# Altium schematic sheet HS40-1177-B09-MAIN BOARD.SchDoc
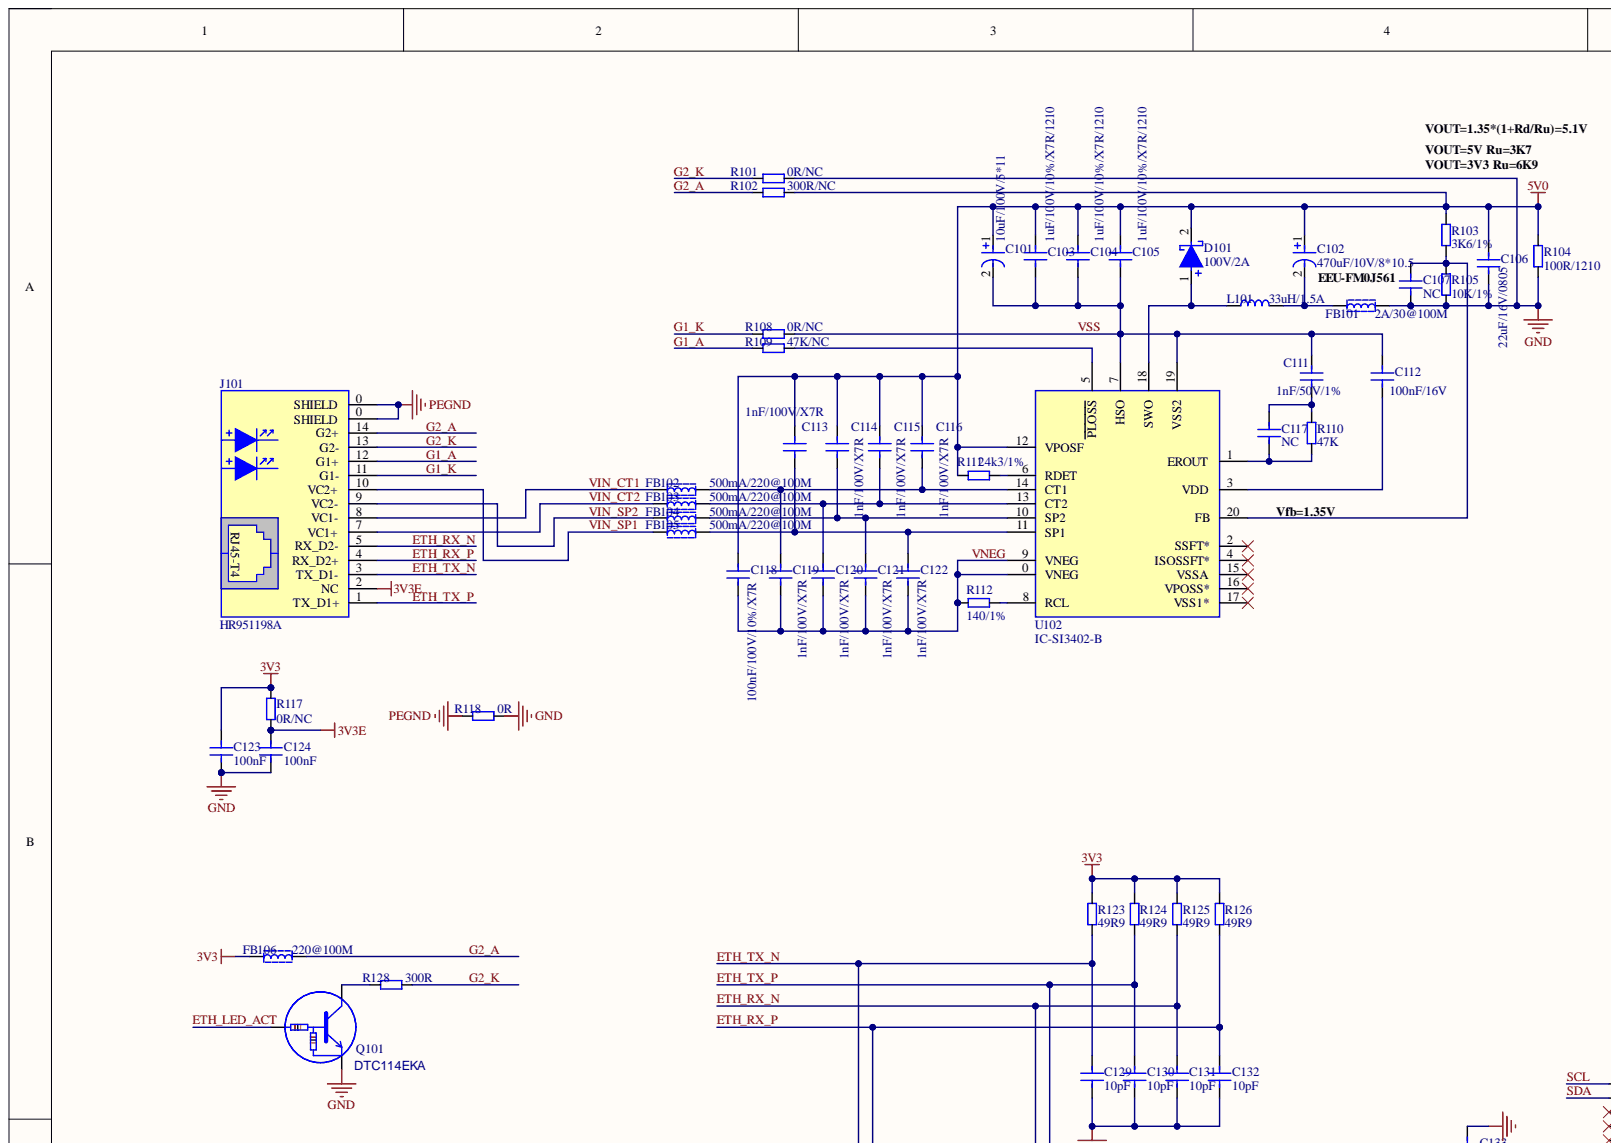
[diagram p0: HS40-1177-B09-MAIN BOARD.SchDoc, top left]
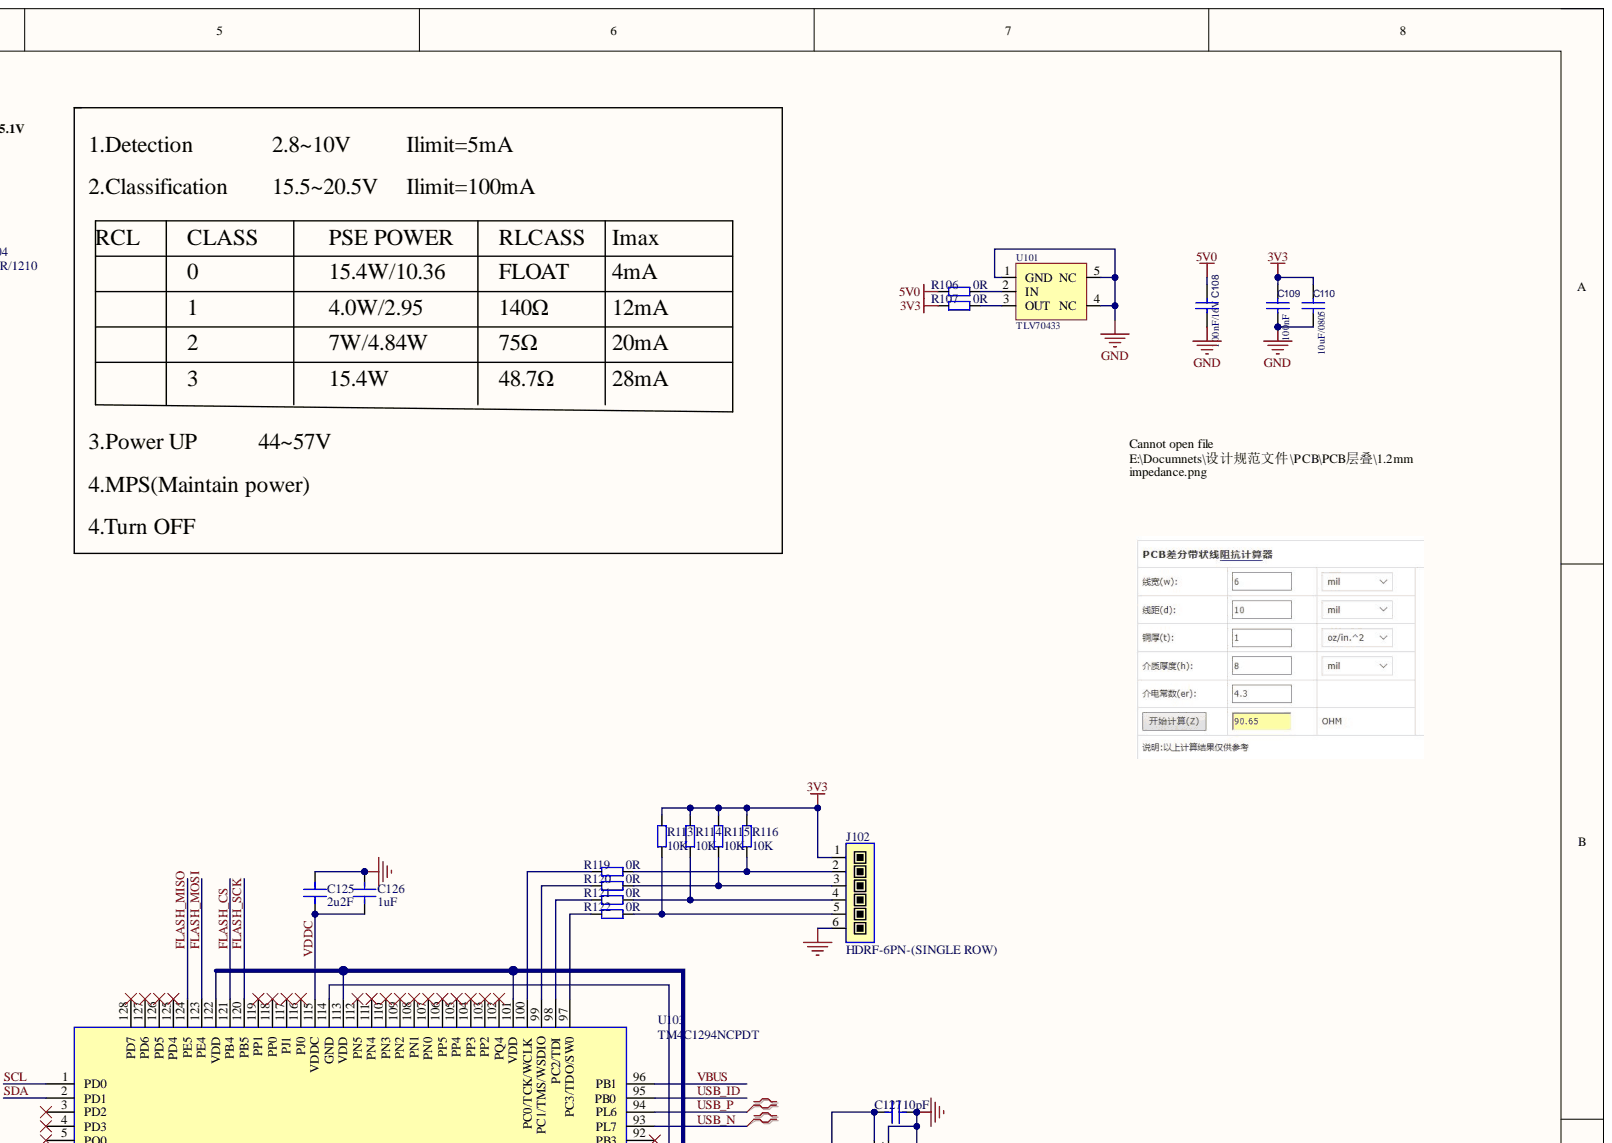
[diagram p1: HS40-1177-B09-MAIN BOARD.SchDoc, top right]
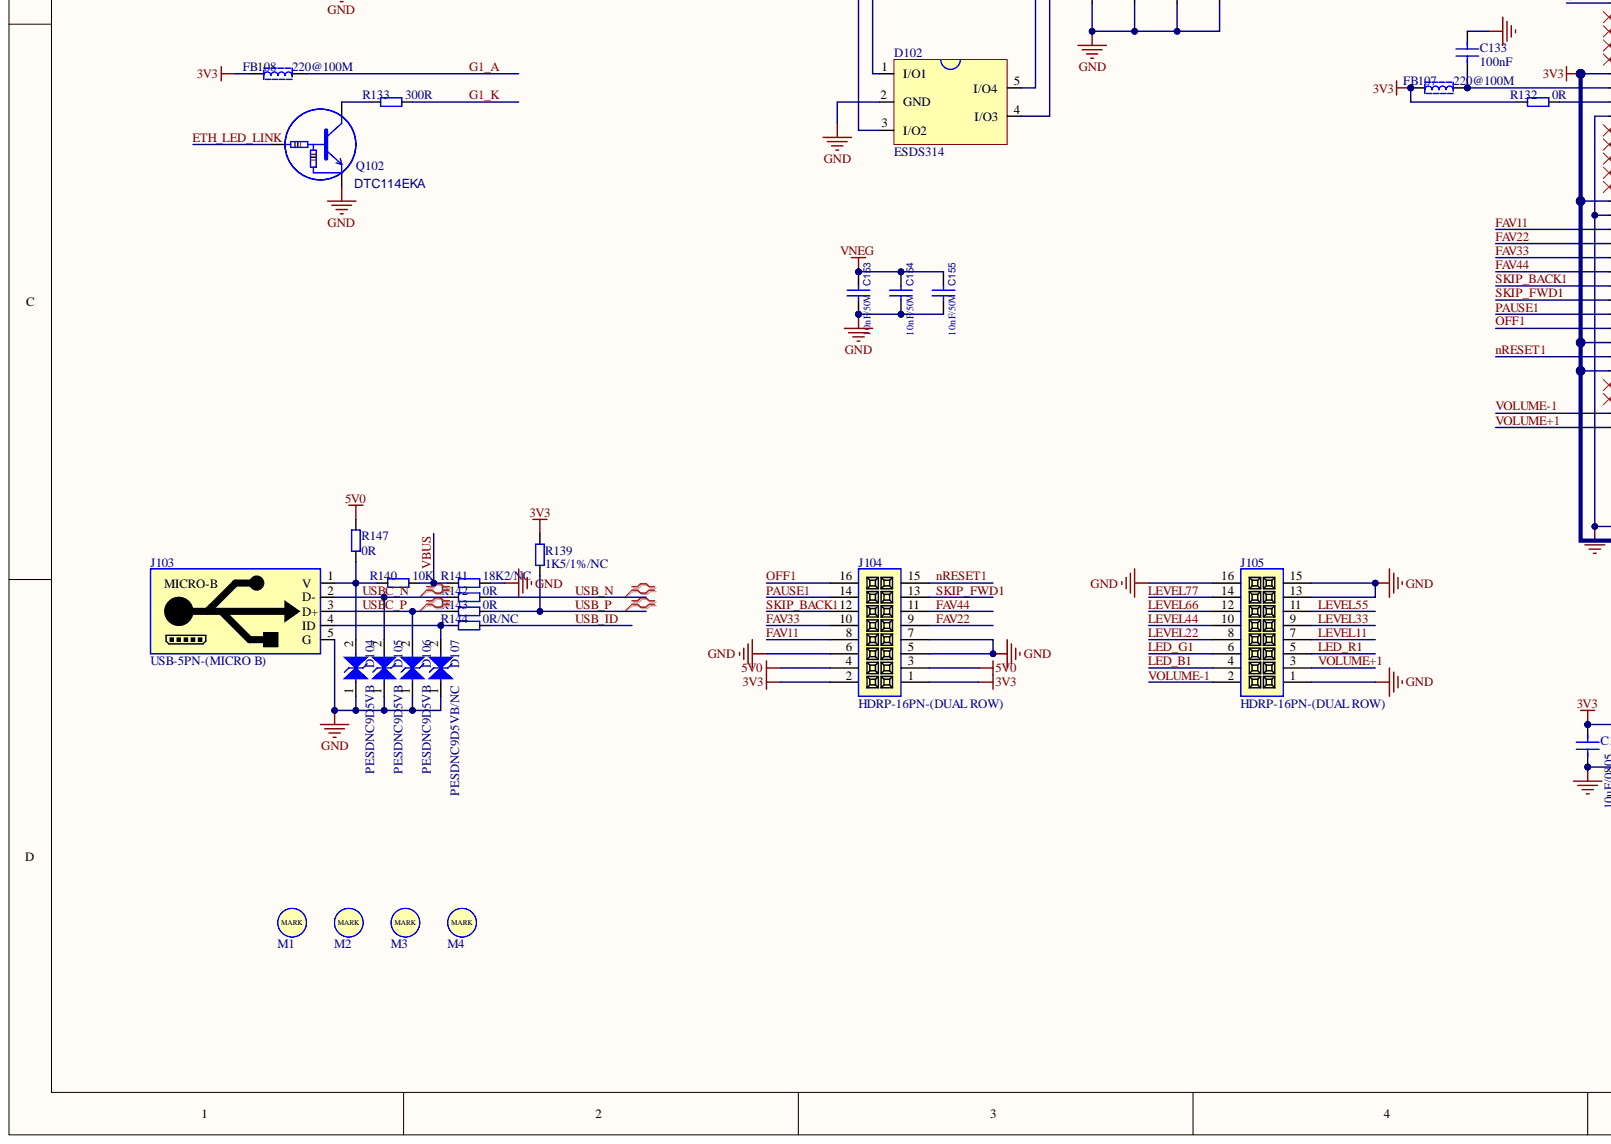
[diagram p2: HS40-1177-B09-MAIN BOARD.SchDoc, bottom left]
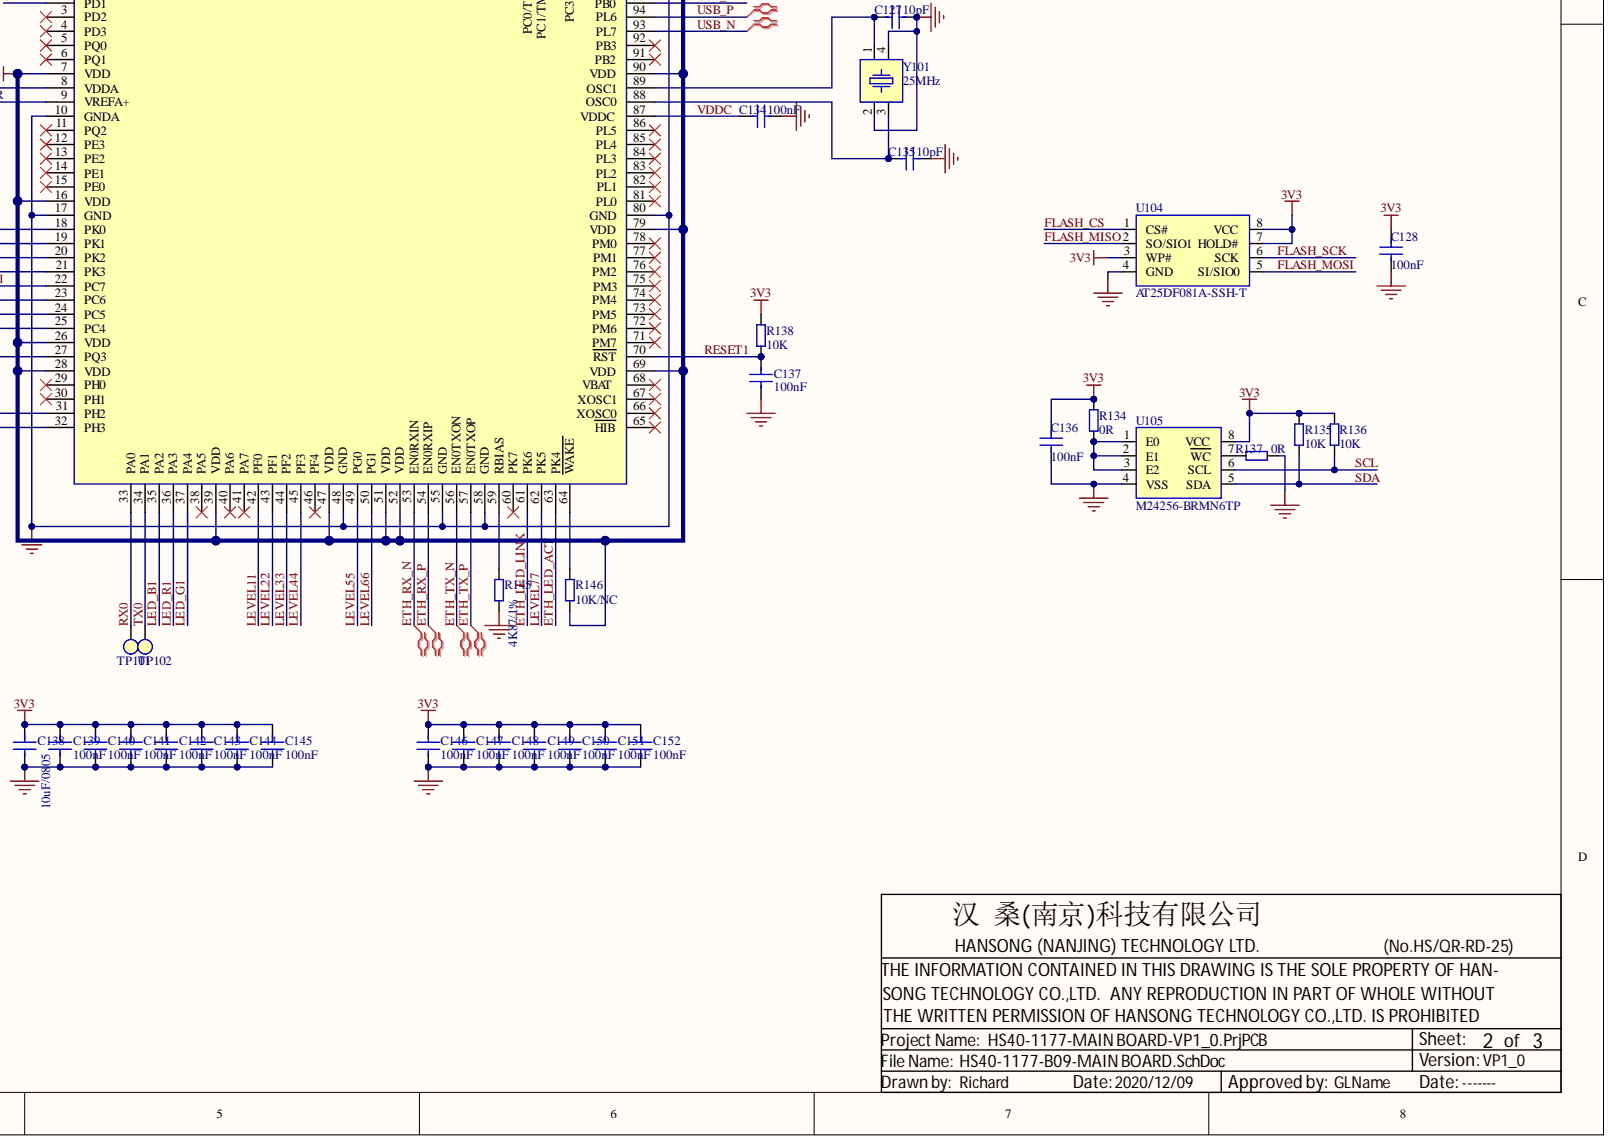
[diagram p3: HS40-1177-B09-MAIN BOARD.SchDoc, bottom right]

## Parts
C101  10uF/100V/5*11  [CE-D-2.5PH-D6.3X11.5]
C102  470uF/10V/8*10.5  [CE-8.3X8.3X12.5-(D8)]
C103  1uF/100V/10%/X7R/1210  [CC-1210]
C104  1uF/100V/10%/X7R/1210  [CC-1210]
C105  1uF/100V/10%/X7R/1210  [CC-1210]
C106  22uF/16V/0805  [CC-0805]
C107  NC  [CC-0603]
C108  100nF/16V  [CC-0402]
C109  100nF  [CC-0402]
C110  10uF/0805  [CC-0805]
C111  1nF/50V/1%  [CC-0603]
C112  100nF/16V  [CC-0402]
C113  1nF/100V/X7R  [CC-0603]
C114  1nF/100V/X7R  [CC-0603]
C115  1nF/100V/X7R  [CC-0603]
C116  1nF/100V/X7R  [CC-0603]
C117  NC  [CC-0603]
C118  100nF/100V/10%/X7R  [CC-0603]
C119  1nF/100V/X7R  [CC-0603]
C120  1nF/100V/X7R  [CC-0603]
C121  1nF/100V/X7R  [CC-0603]
C122  1nF/100V/X7R  [CC-0603]
C123  100nF  [CC-0402]
C124  100nF  [CC-0402]
C125  2u2F  [CC-0603]
C126  1uF  [CC-0603]
C127  10pF  [CC-0402]
C128  100nF  [CC-0402]
C129  10pF  [CC-0402]
C130  10pF  [CC-0402]
C131  10pF  [CC-0402]
C132  10pF  [CC-0402]
C133  100nF  [CC-0402]
C134  100nF  [CC-0402]
C135  10pF  [CC-0402]
C136  100nF  [CC-0402]
C137  100nF  [CC-0402]
C138  10uF/0805  [CC-0805]
C139  100nF  [CC-0402]
C140  100nF  [CC-0402]
C141  100nF  [CC-0402]
C142  100nF  [CC-0402]
C143  100nF  [CC-0402]
C144  100nF  [CC-0402]
C145  100nF  [CC-0402]
C146  100nF  [CC-0402]
C147  100nF  [CC-0402]
C148  100nF  [CC-0402]
C149  100nF  [CC-0402]
C150  100nF  [CC-0402]
C151  100nF  [CC-0402]
C152  100nF  [CC-0402]
C153  10nF/50V  [CC-0402]
C154  10nF/50V  [CC-0402]
C155  10nF/50V  [CC-0402]
D101  100V/2A  [DD-4.4X3.7X2.5-(SMB)]
D102  ESDS314  [ICS-5PN-0.95PH-2.9X1.6X1.5-(SOT23-5)]
D104  PESDNC9D5VB  [DZ-2PN-0.8X0.6X0.5-(SOD923)]
D105  PESDNC9D5VB  [DZ-2PN-0.8X0.6X0.5-(SOD923)]
D106  PESDNC9D5VB  [DZ-2PN-0.8X0.6X0.5-(SOD923)]
D107  PESDNC9D5VB/NC  制造商: PESDNC9D5VB  [DZ-2PN-0.8X0.6X0.5-(SOD923)]
FB101  2A/30@100M  [fb-0402]
FB102  500mA/220@100M  [fb-0402]
FB103  500mA/220@100M  [fb-0402]
FB104  500mA/220@100M  [fb-0402]
FB105  500mA/220@100M  [fb-0402]
FB106  220@100M  [fb-0402]
FB107  220@100M  [fb-0402]
FB108  220@100M  [fb-0402]
J101  HR951198A  [RJ45-D-17.6X16.9X17.5-(2 LED,POST,HR951198A)]
J102  HDRF-6PN-(SINGLE ROW)  [HDRP-D-6PN-2PH-12X2X6-(FOLLOW OTHER,42-2-000039)]
J103  USB-5PN-(MICRO B)  [USB-5PN-0.65PH-8.2X5.62X3.1-(MICRO USB,46-0-000733)]
J104  HDRP-16PN-(DUAL ROW)  [HDRP-16PN-1.27PH-10.16X3.4X5-(DUAL ROW,42-1-000009)]
J105  HDRP-16PN-(DUAL ROW)  [HDRP-16PN-1.27PH-10.16X3.4X5-(DUAL ROW,42-1-000009)]
L101  33uH/1.5A  [LL-2PN-6.5X6X4]
M1  MARK  [MARK-(ROUND,MULTI  LAYER)]
M2  MARK  [MARK-(ROUND,MULTI  LAYER)]
M3  MARK  [MARK-(ROUND,MULTI  LAYER)]
M4  MARK  [MARK-(ROUND,MULTI  LAYER)]
Q101  DTC114EKA  [TS-3PN-2.9X1.3X1.1-(SOT23,B,C,E)]
Q102  DTC114EKA  [TS-3PN-2.9X1.3X1.1-(SOT23,B,C,E)]
R101  0R/NC  [RR-0402]
R102  300R/NC  [RR-0603]
R103  3K6/1%  [RR-0603]
R104  100R/1210  [RR-1210]
R105  10K/1%  [RR-0603]
R106  0R  [RR-0402]
R107  0R  [RR-0402]
R108  0R/NC  [RR-0402]
R109  47K/NC  [RR-0603]
R110  47K  [RR-0603]
R111  24k3/1%  [RR-0603]
R112  140/1%  [RR-0603]
R113  10K  [RR-0402]
R114  10K  [RR-0402]
R115  10K  [RR-0402]
R116  10K  [RR-0402]
R117  0R/NC  [RR-0402]
R118  0R  [RR-0402]
R119  0R  [RR-0402]
R120  0R  [RR-0402]
R121  0R  [RR-0402]
R122  0R  [RR-0402]
R123  49R9  [RR-0603]
R124  49R9  [RR-0603]
R125  49R9  [RR-0603]
R126  49R9  [RR-0603]
R128  300R  [RR-0603]
R132  0R  [RR-0402]
R133  300R  [RR-0603]
R134  0R  [RR-0402]
R135  10K  [RR-0402]
R136  10K  [RR-0402]
R137  0R  [RR-0402]
R138  10K  [RR-0402]
R139  1K5/1%/NC  [RR-0402]
R140  10K  [RR-0402]
R141  18K2/NC  [RR-0402]
R142  0R  [RR-0402]
R143  0R  [RR-0402]
R144  0R/NC  [RR-0402]
R145  4K87/1%  [RR-0603]
R146  10K/NC  [RR-0402]
R147  0R  [RR-0402]
TP101  TP  [TP-D0.8]
TP102  TP  [TP-D0.8]
U101  TLV70433  [ICS-5PN-0.95PH-2.9X1.6X1.5-(SOT23-5)]
U102  IC-SI3402-B  [ICQ-20PN-0.8PH-5X5X0.9-(QFN,THERMAL PAD,Si3402-B)]
U103  TM4C1294NCPDT  [ICQ-128PN-0.4PH-14X14X1.6-(LQFP128,NO DATASHEET)]
U104  AT25DF081A-SSH-T  [ICS-8PN-1.27PH-5.28X5.28X2.2-(SOIC,NO DATASHEET)]
U105  M24256-BRMN6TP  [ICS-8PN-1.27PH-4.9X3.9X1.8-(SOP8)]
Y101  25MHz  [XL-4PN-3.2X2.5X0.8]

## Nets
3V3: C109.2 C110.2 C123.2 C128.2 C136.2 C138.2 C139.2 C140.2 C141.2 C142.2 C143.2 C144.2 C145.2 C146.2 C147.2 C148.2 C149.2 C150.2 C151.2 C152.2 FB106.1 FB107.1 FB108.1 J102.1 J104.1 J104.2 R107.2 R113.2 R114.2 R115.2 R116.2 R117.1 R123.1 R124.1 R125.1 R126.1 R132.2 R134.1 R135.2 R136.2 R138.2 R139.1 R146.1 U103.7(VDD) U103.16(VDD) U103.26(VDD) U103.28(VDD) U103.39(VDD) U103.47(VDD) U103.51(VDD) U103.52(VDD) U103.69(VDD) U103.79(VDD) U103.90(VDD) U103.101(VDD) U103.113(VDD) U103.122(VDD) U104.3(WP#) U104.7(HOLD#) U104.8(VCC) U105.8(VCC)
3V3E: C124.2 J101.2(NC) R117.2
5V0: C101.1 C102.1 C103.2 C104.2 C105.2 C106.2 C108.2 C113.2 C114.2 C115.2 C116.2 C118.2 D101.2 J104.3 J104.4 R102.1 R103.2 R104.2 R106.2 R111.2 R147.1 U102.12(VPOSF)
GND: C106.1 C107.1 C108.1 C109.1 C110.1 C123.1 C124.1 C125.2 C126.2 C127.1 C128.1 C129.1 C130.1 C131.1 C132.1 C133.1 C134.1 C135.1 C136.1 C137.1 C138.1 C139.1 C140.1 C141.1 C142.1 C143.1 C144.1 C145.1 C146.1 C147.1 C148.1 C149.1 C150.1 C151.1 C152.1 C153.1 C154.1 C155.1 D102.2(GND) D104.1 D105.1 D106.1 D107.1 FB101.1 J102.6 J103.5(G) J104.5 J104.6 J104.7 J105.1 J105.13 J105.15 J105.16 Q101.e Q102.e R101.1 R104.1 R105.1 R118.2 R137.2 R141.1 R145.1 U101.1(GND) U101.4(NC) U101.5(NC) U103.10(GNDA) U103.17(GND) U103.48(GND) U103.55(GND) U103.58(GND) U103.80(GND) U103.114(GND) U104.4(GND) U105.4(VSS) Y101.2 Y101.4
PEGND: J101.0(SHIELD) R118.1
ETH_LED_ACT: Q101.b U103.63
ETH_LED_LINK: Q102.b U103.61(PK6)
ETH_RX_N: C131.2 D102.5(I/O4) J101.5(RX_D2-) R125.2 U103.53(EN0RXIN)
ETH_RX_P: C132.2 D102.1(I/O1) J101.4(RX_D2+) R126.2 U103.54(EN0RXIP)
ETH_TX_N: C129.2 D102.3(I/O2) J101.3(TX_D1-) R123.2 U103.56(EN0TXON)
ETH_TX_P: C130.2 D102.4(I/O3) J101.1(TX_D1+) R124.2 U103.57(EN0TXOP)
FAV11: J104.8 U103.18(PK0)
FAV22: J104.9 U103.19(PK1)
FAV33: J104.10 U103.20(PK2)
FAV44: J104.11 U103.21(PK3)
FLASH_CS: U103.121(PB4) U104.1(CS#)
FLASH_MISO: U103.124(PE5) U104.2(SO/SIO1)
FLASH_MOSI: U103.123(PE4) U104.5(SI/SIO0)
FLASH_SCK: U103.120(PB5) U104.6(SCK)
G1_A: FB108.2 J101.12(G1+) R109.2
G1_K: J101.11(G1-) R108.2 R133.1
G2_A: FB106.2 J101.14(G2+) R102.2
G2_K: J101.13(G2-) R101.2 R128.1
LED_B1: J105.4 U103.35(PA2)
LED_G1: J105.6 U103.37(PA4)
LED_R1: J105.5 U103.36(PA3)
LEVEL11: J105.7 U103.42(PF0)
LEVEL22: J105.8 U103.43(PF1)
LEVEL33: J105.9 U103.44(PF2)
LEVEL44: J105.10 U103.45(PF3)
LEVEL55: J105.11 U103.49
LEVEL66: J105.12 U103.50(PG1)
LEVEL77: J105.14 U103.62(PK5)
nRESET1: J104.15 U103.27(PQ3)
OFF1: J104.16 U103.25(PC4)
PAUSE1: J104.14 U103.24(PC5)
RESET1: C137.2 R138.1 U103.70(RST)
RX0: TP101.1 U103.33(PA0)
SCL: R136.1 U103.1(PD0) U105.6(SCL)
SDA: R135.1 U103.2(PD1) U105.5(SDA)
SKIP_BACK1: J104.12 U103.22(PC7)
SKIP_FWD1: J104.13 U103.23(PC6)
TX0: TP102.1 U103.34(PA1)
USB_ID: R144.1 U103.95(PB0)
USB_N: R142.1 U103.93(PL7)
USB_P: R139.2 R143.1 U103.94(PL6)
USBC_N: D105.2 J103.2(D-) R142.2
USBC_P: D106.2 J103.3(D+) R143.2
VBUS: R140.1 R141.2 U103.96(PB1)
VDDC: C125.1 C126.1 C134.2 U103.87(VDDC) U103.115(VDDC)
VIN_CT1: FB102.2 J101.8(VC1-)
VIN_CT2: FB103.2 J101.7(VC1+)
VIN_SP1: FB105.2 J101.10(VC2+)
VIN_SP2: FB104.2 J101.9(VC2-)
VNEG: C118.1 C119.1 C120.1 C121.1 C122.1 C153.2 C154.2 C155.2 R112.2 U102.0(VNEG) U102.9(VNEG)
VOLUME+1: J105.3 U103.32(PH3)
VOLUME-1: J105.2 U103.31(PH2)
VSS: C101.2 C103.1 C104.1 C105.1 C111.2 C112.2 R108.1 U102.7(HSO) U102.19(VSS2)
NetC102_2: C102.2 FB101.2 L101.1
NetC107_2: C107.2 R103.1 R105.2 U102.20(FB)
NetC111_1: C111.1 C117.2 R110.2
NetC112_1: C112.1 U102.3(VDD)
NetC113_1: C113.1 C122.2 FB105.1 U102.11(SP1)
NetC114_1: C114.1 C121.2 FB104.1 U102.10(SP2)
NetC115_1: C115.1 C120.2 FB103.1 U102.13(CT2)
NetC116_1: C116.1 C119.2 FB102.1 U102.14(CT1)
NetC117_1: C117.1 R110.1 U102.1(EROUT)
NetC127_2: C127.2 U103.89(OSC1) Y101.1
NetC133_2: C133.2 FB107.2 U103.8(VDDA)
NetC135_2: C135.2 U103.88(OSC0) Y101.3
NetD101_1: D101.1 L101.2 U102.18(SWO)
NetD104_2: D104.2 J103.1(V) R140.2 R147.2
NetD107_2: D107.2 J103.4(ID) R144.2
NetJ102_2: J102.2 R116.1 R119.1
NetJ102_3: J102.3 R115.1 R120.1
NetJ102_4: J102.4 R114.1 R121.1
NetJ102_5: J102.5 R113.1 R122.1
NetQ101_c: Q101.c R128.2
NetQ102_c: Q102.c R133.2
NetR106_1: R106.1 U101.2(IN)
NetR107_1: R107.1 U101.3(OUT)
NetR109_1: R109.1 U102.5(PLOSS)
NetR111_1: R111.1 U102.6(RDET)
NetR112_1: R112.1 U102.8(RCL)
NetR119_2: R119.2 U103.100(PC0/TCK/WCLK)
NetR120_2: R120.2 U103.99(PC1/TMS/WSDIO)
NetR121_2: R121.2 U103.98
NetR122_2: R122.2 U103.97(PC3/TDO/SW0)
NetR132_1: R132.1 U103.9(VREFA+)
NetR134_2: R134.2 U105.1(E0) U105.2(E1) U105.3(E2)
NetR137_1: R137.1 U105.7(WC)
NetR145_2: R145.2 U103.59(RBIAS)
NetR146_2: R146.2 U103.64(WAKE)

Not connected: U102 2(SSFT*) 4(ISOSSFT*) 15(VSSA) 16(VPOSS*) 17(VSS1*); U103 3(PD2) 4(PD3) 5(PQ0) 6(PQ1) 11(PQ2) 12(PE3) 13(PE2) 14(PE1) 15(PE0) 29(PH0) 30(PH1) 38(PA5) 40(PA6) 41(PA7) 46(PF4) 60(PK7) 65(HIB) 66(XOSC0) 67(XOSC1) 68(VBAT) 71(PM7) 72(PM6) 73(PM5) 74(PM4) 75(PM3) 76(PM2) 77(PM1) 78(PM0) 81(PL0) 82(PL1) 83(PL2) 84(PL3) 85(PL4) 86(PL5) 91(PB2) 92(PB3) 102(PQ4) 103(PP2) 104(PP3) 105(PP4) 106(PP5) 107(PN0) 108(PN1) 109(PN2) 110(PN3) 111(PN4) 112 116(PJ0) 117(PJ1) 118(PP0) 119(PP1) 125(PD4) 126(PD5) 127(PD6) 128(PD7)

## Library data of the parts
C101 = CE: Electrolytic Capacitor; ABC等级: C; 封装: Pitch=2.5mm,5*11mm; 计量单位: pcs; 交期(天): 90; 旧品号: 06-10012-04; 品号规格: RD-series,10uF,100V,5*11/F2.5; 品号类型: 采购件; 品号状态: 正常(Active); 品号状态1: 生效; 品名: 编带铝电解电容; 品牌: Samwha; 确认状态: 确认; 湿敏: 0; 新品号: 23-2-000081; 英文名: Alu Electrolytic Capacitor; 制造商型号: RD2A106M05011PC380; ABC等级1: C; Component Kind: Standard; Pin: 0; 创建日期: 2015/5/8 13:56:38; 限量: 0; Library Name: Hansong-Standard SCH Library.SchLib
C102 = CE: Electrolytic Capacitor; ABC等级: C; 封装: 8×10.5mm; 计量单位: pcs; 交期(天): 63; 旧品号: 06-47111-27; 品号规格: 470uF,10V,±20%,105℃, VZH471M1ATR-0810; 品号类型: 采购件; 品号状态: 正常(Active); 品号状态1: 生效; 品名: 贴片铝电解电容; 品牌: LELON; 确认状态: 确认; 湿敏: 0; 新品号: 23-1-000010; 英文名: Chip Alu Electrolytic Capacitor; 制造商型号: VZH471M1ATR-0810; ABC等级1: C; Component Kind: Standard; Pin: 2; 创建日期: 2015/5/8 12:35:51; 限量: 0; Library Name: Hansong-Standard SCH Library.SchLib
C103-C105 = CC: Capacitor; ABC等级: C; 计量单位: pcs; 交期(天): 49; 旧品号: 26-10512-23; 品号规格: 1uF,100V,±10%,1210,X7R,HMK325B7105KN-T; 品号类型: 采购件; 品号状态: 正常(Active); 品号状态1: 生效; 品名: 贴片瓷片电容; 品牌: Taiyou; 确认状态: 确认; 限量: 0; 新品号: 23-1-000667; 英文名: Ceramic Chip Capacitor; 制造商: HMK325B7105KN-T; ABC等级1: C; Component Kind: Standard; Pin: 0; 创建日期: 2015/11/20 9:05:12; Library Name: Hansong-Standard SCH Library.SchLib
C106 = CC: Capacitor; ABC等级: C; 封装: 0805; 计量单位: pcs; 交期(天): 49; 品号规格: 22uK,16V,0805,X5R,CL21A226KOQNNNE; 品号类型: 采购件; 品号状态: 正常(Active); 品号状态1: 生效; 品名: 贴片瓷片电容; 品牌: Samsung; 确认状态: 确认; 湿敏: 0; 新品号: 23-1-001341; 英文名: Ceramic Chip Capacitor; 制造商型号: CL21A226KOQNNNE; ABC等级1: C; Component Kind: Standard; Pin: 2; 创建日期: 2018/5/9 15:05:55; 限量: 0; Library Name: Hansong-Standard SCH Library.SchLib
C107 C117 = CC: Capacitor; Component Kind: Standard; Library Name: Hansong-Standard SCH Library.SchLib
C108 C109 = Cap Semi: Capacitor (Semiconductor SIM Model); ABC等级: C; 封装: 0402; 计量单位: pcs; 交期(天): 49; 品号规格: 100nK,16V,0402,X7R,0402B104K160NT; 品号类型: 采购件; 品号状态: 正常(Active); 品号状态1: 生效; 品名: 贴片瓷片电容; 品牌: FH; 确认状态: 确认; 湿敏: 0; 新品号: 23-1-001652; 英文名: Ceramic Chip Capacitor; 制造商型号: 0402B104K160NT; ABC等级1: C; Component Kind: Standard; Pin: 2; 创建日期: 2018/11/20 17:08:58; 限量: 0
C110 = Cap Semi: Capacitor (Semiconductor SIM Model); ABC等级: C; 封装: 0805; 计量单位: pcs; 交期(天): 49; 旧品号: 26-10611-21; 品号规格: 10uK,16V,0805,X5R,C2012X5R1C106KT / CC0805KKX5R7BB106; 品号类型: 采购件; 品号状态: 正常(Active); 品号状态1: 生效; 品名: 贴片瓷片电容; 品牌: TDK/Phicomp; 确认状态: 确认; 湿敏: 0; 新品号: 23-1-000039; 英文名: Ceramic Chip Capacitor; ABC等级1: C; Component Kind: Standard; Pin: 2; 创建日期: 2015/5/8 12:35:54; 限量: 0
C111 C113-C116 C119-C122 = CC: Capacitor; ABC等级: C; 封装: 0603; 计量单位: pcs; 交期(天): 49; 旧品号: 26-10212-00; 品号规格: 1nK,100V,0603,X7R,C1608X7R2A102KT000N; 品号类型: 采购件; 品号状态: 正常(Active); 品号状态1: 生效; 品名: 贴片电容; 品牌: TDK/Phicomp; 确认状态: 确认; 湿敏: 0; 新品号: 23-1-000196; 英文名: Ceramic Chip Capacitor; 制造商: C1608X7R2A102KT000N; ABC等级1: C; Component Kind: Standard; Pin: 2; 创建日期: 2015/5/8 13:54:21; 限量: 0; 制造商型号: C1608X7R2A102KT000N; Library Name: Hansong-Standard SCH Library.SchLib
C112 C123 C124 C128 C133 C134 C136 C137 C139-C152 = CC: Capacitor; ABC等级: C; 封装: 0402; 计量单位: pcs; 交期(天): 49; 品号规格: 100nK,16V,0402,X7R,0402B104K160NT; 品号类型: 采购件; 品号状态: 正常(Active); 品号状态1: 生效; 品名: 贴片瓷片电容; 品牌: FH; 确认状态: 确认; 湿敏: 0; 新品号: 23-1-001652; 英文名: Ceramic Chip Capacitor; 制造商型号: 0402B104K160NT; ABC等级1: C; Component Kind: Standard; Pin: 2; 创建日期: 2018/11/20 17:08:58; 限量: 0; Library Name: Hansong-Standard SCH Library.SchLib
C118 = CC: Capacitor; ABC等级: C; 封装: 0603; 计量单位: pcs; 交期(天): 49; 品号规格: 100nK,100V,0603,X7R,CL10B104KC8NNNC; 品号类型: 采购件; 品号状态: 正常(Active); 品号状态1: 生效; 品名: 贴片瓷片电容; 品牌: Samsung; 确认状态: 确认; 湿敏: 0; 新品号: 23-1-001223; 英文名: Ceramic Chip Capacitor; 制造商型号: CL10B104KC8NNNC; ABC等级1: C; Component Kind: Standard; Pin: 2; 创建日期: 2018/3/12 16:47:24; 限量: 0; Library Name: Hansong-Standard SCH Library.SchLib
C125 = CC: Capacitor; ABC等级: C; 封装: 0603; 计量单位: pcs; 交期(天): 49; 旧品号: 26-22511-10; 品号规格: 2.2uK, 0603, 10V, X5R,CC0603KRX5R6BB225; 品号类型: 采购件; 品号状态: 正常(Active); 品号状态1: 生效; 品名: 贴片瓷片电容; 品牌: TDK/Yageo/和伸堂; 确认状态: 确认; 湿敏: 0; 新品号: 23-1-000054; 英文名: Ceramic Capacitor SMD; 制造商: CC0603KRX5R6BB225; ABC等级1: C; Component Kind: Standard; Pin: 2; 创建日期: 2015/5/8 12:35:56; 限量: 0; 制造商型号: CC0603KRX5R6BB225; Library Name: Hansong-Standard SCH Library.SchLib
C126 = CC: Capacitor; ABC等级: C; 封装: 0603; 计量单位: pcs; 交期(天): 49; 品号规格: 1uK,16V,0603,X7R,CL10B105KO8NNNC; 品号类型: 采购件; 品号状态: 正常(Active); 品号状态1: 生效; 品名: 贴片瓷片电容; 品牌: Samsung; 确认状态: 确认; 湿敏: 0; 新品号: 23-1-001131; 英文名: Ceramic Chip Capacitor; 制造商型号: CL10B105KO8NNNC; ABC等级1: C; Component Kind: Standard; Pin: 2; 创建日期: 2017/12/7 10:29:37; 限量: 0; Library Name: Hansong-Standard SCH Library.SchLib
C127 C129-C132 C135 = CC: Capacitor; ABC等级: C; 封装: 0402; 计量单位: pcs; 交期(天): 49; 品号规格: 10pJ,50V,0402,NP0,0402CG100J500NT; 品号类型: 采购件; 品号状态: 正常(Active); 品号状态1: 生效; 品名: 贴片瓷片电容; 品牌: FH; 确认状态: 确认; 湿敏: 0; 新品号: 23-1-001364; 英文名: Ceramic Chip Capacitor; 制造商型号: 0402CG100J500NT; ABC等级1: C; Component Kind: Standard; Pin: 2; 创建日期: 2018/5/10 9:47:55; 限量: 0; Library Name: Hansong-Standard SCH Library.SchLib
C138 = CC: Capacitor; ABC等级: C; 封装: 0805; 计量单位: pcs; 交期(天): 49; 旧品号: 26-10611-21; 品号规格: 10uK,16V,0805,X5R,C2012X5R1C106KT / CC0805KKX5R7BB106; 品号类型: 采购件; 品号状态: 正常(Active); 品号状态1: 生效; 品名: 贴片瓷片电容; 品牌: TDK/Phicomp; 确认状态: 确认; 湿敏: 0; 新品号: 23-1-000039; 英文名: Ceramic Chip Capacitor; ABC等级1: C; Component Kind: Standard; Pin: 2; 创建日期: 2015/5/8 12:35:54; 限量: 0; Library Name: Hansong-Standard SCH Library.SchLib
C153-C155 = Cap Semi: Capacitor (Semiconductor SIM Model); ABC等级: B; 封装: 0402; 计量单位: pcs; 交期(天): 49; 品号规格: 10nK,50V,0402,X7R,0402B103K500NT; 品号类型: 采购件; 品号状态: 正常(Active); 品号状态1: 生效; 品名: 贴片瓷片电容; 品牌: FH; 确认状态: 确认; 湿敏: 0; 新品号: 23-1-001159; 英文名: Ceramic Chip Capacitor; 制造商型号: 0402B103K500NT; ABC等级1: B; Component Kind: Standard; Pin: 2; 创建日期: 2017/12/15 11:05:01; 限量: 0
D101 = DS: Schottky BarrierDiode Diode; ABC等级: C; 封装: SMB; 计量单位: pcs; 交期(天): 30; 旧品号: 33-20002-03; 品号规格: ES2B,100V 2A; 品号类型: 采购件; 品号状态: 正常(Active); 品号状态1: 生效; 品名: 二极管; 品牌: TRR; 确认状态: 确认; 湿敏: 0; 新品号: 12-1-000151; 制造商: ES2B; ABC等级1: C; Component Kind: Standard; Pin: 2; 创建日期: 2015/5/8 13:42:53; 限量: 0; 英文名: Diode; 制造商型号: ES2B; Library Name: Hansong-Standard SCH Library.SchLib
D102 = TLV70233: ABC等级: B; PN: NEW; 封装: SOT23(5); 计量单位: pcs; 交期(天): 90; 品号规格: ESDS314,SOT23(5); 品号类型: 采购件; 品号状态: 正常(Active); 品号状态1: 生效; 品名: 贴片ESD保护IC; 品牌: TI; 确认状态: 确认; 湿敏: 1; 限量: 0; 新品号: 11-1-002193; 英文名: ESD Production IC; ABC等级1: B; Component Kind: Standard; Library Name: M33.SchLib; Pin: 5; 创建日期: 2019/10/31 12:35:17; 制造商型号: ESDS314
D104-D107 = DZ-(TVS): Zener Diode; ABC等级: C; 封装: SOD923; 计量单位: pcs; 交期(天): 42; 旧品号: 33-00905-02; 品号规格: PESDNC9D5VB,5V,80W; 品号类型: 采购件; 品号状态: 正常(Active); 品号状态1: 生效; 品名: 静电和瞬变电压抑制二极管; 品牌: Prisemi; 确认状态: 确认; 湿敏: 0; 新品号: 12-1-000041; 英文名: ESD TVS DIODE; ABC等级1: C; Component Kind: Standard; Pin: 2; 创建日期: 2015/5/8 12:21:59; 限量: 0; 制造商型号: PESDNC9D5VB; Library Name: Hansong-Standard SCH Library.SchLib
  D104-D106: 制造商: PESDNC9D5VB
FB101-FB105 = FB: Ferrite Bead; ABC等级: B; 封装: 0402; 计量单位: pcs; 交期(天): 80; 旧品号: 08-04121-18; 品号规格: 120ohm@100MHz,1.3A,±25%,0402,BLM15PD121SN1D; 品号类型: 采购件; 品号状态: 正常(Active); 品号状态1: 生效; 品名: 贴片磁珠; 品牌: Murata; 确认状态: 确认; 湿敏: 0; 新品号: 24-1-000066; 制造商: BLM15PD121SN1D; ABC等级1: B; Component Kind: Standard; Pin: 2; 创建日期: 2015/5/8 12:44:06; 限量: 0; 英文名: FERRITE; 制造商型号: BLM15PD121SN1D; Library Name: Hansong-Standard SCH Library.SchLib
FB106-FB108 = FB: Ferrite Bead; ABC等级: B; 封装: 0402; 计量单位: pcs; 交期(天): 55; 旧品号: 08-04121-17; 品号规格: 120ohm@100MHz,0.3A,±25%,0402,BLM15BD121SN1D; 品号类型: 采购件; 品号状态: 正常(Active); 品号状态1: 生效; 品名: 贴片磁珠; 品牌: Murata; 确认状态: 确认; 湿敏: 0; 新品号: 24-1-000065; 制造商: BLM15BD121SN1D; ABC等级1: B; Component Kind: Standard; Pin: 2; 创建日期: 2015/5/8 12:44:05; 限量: 0; 英文名: FERRITE; 制造商型号: BLM15BD121SN1D; Library Name: Hansong-Standard SCH Library.SchLib
J102 = HDRF-6PN-(SINGLE ROW): Female Header; Component Kind: Standard; Library Name: Hansong-Standard SCH Library.SchLib
J103 = USB-5PN-(MICRO B): USB; 计量单位: pcs; 交期(天): 45; 品号规格: MC-100503027-R; 品号类型: 采购件; 品号状态: 正常(Active); 品号状态1: 生效; 品名: 插件Micro USB接口; 品牌: 正宝; 确认状态: 确认; 湿敏: 0; 新品号: 46-0-000733; 英文名: Micro-USB; ABC等级: B; ABC等级1: B; Component Kind: Standard; Pin: 0; 创建日期: 2020/5/21 16:47:53; 限量: 0; 制造商型号: MC-100503027-R; Library Name: Hansong-Standard SCH Library.SchLib
J104 J105 = HDRP-16PN-(DUAL ROW): Pin Header; ABC等级: C; 计量单位: pcs; 交期(天): 25; 旧品号: 13-11216-02; 品号规格: 1.27mm间距,2*8Pin,CNT-M127-2*8-GM-3.5/1.5/5-100-TR; 品号类型: 采购件; 品号状态: 正常(Active); 品号状态1: 生效; 品名: 贴片双排直针; 品牌: CNT; 确认状态: 确认; 湿敏: 0; 新品号: 42-1-000009; 英文名: Connector; 制造商: CNT-M127-2*8-GM-3.5/1.5/5-100-TR; ABC等级1: C; Component Kind: Standard; Pin: 16; 创建日期: 2015/5/8 12:50:08; 限量: 0; 制造商型号: CNT-M127-2*8-GM-3.5/1.5/5-100-TR; Library Name: Hansong-Standard SCH Library.SchLib
L101 = LL: Inductor; ABC等级: B; 封装: 6045; 计量单位: pcs; 交期(天): 55; 品号规格: 33uH,±20%,6045,HPC6045NF-330M; 品号类型: 采购件; 品号状态: 正常(Active); 品号状态1: 生效; 品名: 贴片功率电感; 品牌: 台庆; 确认状态: 确认; 湿敏: 0; 新品号: 24-1-000518; 英文名: Power Inductors; 制造商型号: HPC6045NF-330M; ABC等级1: B; Component Kind: Standard; Pin: 2; 创建日期: 2018/6/29 17:03:22; 限量: 0; Library Name: Hansong-Standard SCH Library.SchLib
Q101 Q102 = DTC114EKA: 31-00114-05; ABC等级: B; Text Field1: 数字三极管; Text Field2: 310011405; Text Field3: DTC114, SOT23, Tape; Text Field4: DTC114EKA, Tape; Text Field5: ROHM; 封装: SOT23; 计量单位: pcs; 交期(天): 112; 品号规格: DTC114EKAT146; 品号类型: 采购件; 品号状态: 正常(Active); 品号状态1: 生效; 品名: 贴片数字三极管; 确认状态: 确认; 湿敏: 0; 新品号: 13-1-000005; 英文名: Digital transistor; ABC等级1: B; Component Kind: Standard; Pin: 3; 创建日期: 2015/5/8 12:24:05; 旧品号: 31-00114-05; 品牌: ROHM; 限量: 0
R101 R106-R108 R117-R122 R132 R134 R137 R142-R144 R147 = RR: Resistor; ABC等级: C; 封装: 0402; 计量单位: pcs; 交期(天): 49; 旧品号: 07-90000-08; 品号规格: 0Ω,0402,1/16W,±5%,RC0402JR-070RL; 品号类型: 采购件; 品号状态: 正常(Active); 品号状态1: 生效; 品名: 贴片电阻; 品牌: Yageo/Phicomp; 确认状态: 确认; 湿敏: 0; 新品号: 21-1-000004; 英文名: Chip Resistor; 制造商型号: RC0402JR-070RL; ABC等级1: C; Component Kind: Standard; Pin: 2; 创建日期: 2015/5/8 12:25:49; 限量: 0; Library Name: Hansong-Standard SCH Library.SchLib
R102 R128 R133 = RR: Resistor; ABC等级: C; 封装: 0603; 计量单位: pcs; 交期(天): 49; 旧品号: 07-93000-00; 品号规格: 300Ω,0603,1/10W,±1%,RC0603FR-07300RL; 品号类型: 采购件; 品号状态: 正常(Active); 品号状态1: 生效; 品名: 贴片电阻; 品牌: Yageo/Phicomp; 确认状态: 确认; 湿敏: 0; 新品号: 21-1-000920; 英文名: Chip Resistor; ABC等级1: C; Component Kind: Standard; Pin: 2; 创建日期: 2015/5/8 13:48:55; 限量: 0; Library Name: Hansong-Standard SCH Library.SchLib
R103 = RR: Resistor; ABC等级: C; 封装: 0603; 计量单位: pcs; 交期(天): 49; 旧品号: 07-93601-00; 品号规格: 3.6KΩ,0603,1/10W,±1%,RC0603FR-073K6L; 品号类型: 采购件; 品号状态: 正常(Active); 品号状态1: 生效; 品名: 贴片电阻; 品牌: Yageo/Phicomp; 确认状态: 确认; 湿敏: 0; 新品号: 21-1-001005; 英文名: Chip resistor; ABC等级1: C; Component Kind: Standard; Pin: 2; 创建日期: 2015/5/8 13:49:23; 限量: 0; Library Name: Hansong-Standard SCH Library.SchLib
R104 = RR: Resistor; ABC等级: C; 封装: 1210; 计量单位: pcs; 交期(天): 49; 旧品号: 07-90101-03; 品号规格: 100Ω,1210,1/2W,±5%,RC1210JR-07100RL; 品号类型: 采购件; 品号状态: 正常(Active); 品号状态1: 生效; 品名: 贴片电阻; 品牌: Yageo/Phicomp; 确认状态: 确认; 湿敏: 0; 新品号: 21-1-000137; 英文名: Chip Resistor; 制造商型号: RC1210JR-07100RL; ABC等级1: C; Component Kind: Standard; Pin: 2; 创建日期: 2015/5/8 13:45:14; 限量: 0; Library Name: Hansong-Standard SCH Library.SchLib
R105 = RR: Resistor; ABC等级: C; 封装: 0603; 计量单位: pcs; 交期(天): 49; 旧品号: 07-91002-00; 品号规格: 10KΩ,0603,1/10W,±1%; 品号类型: 采购件; 品号状态: 正常(Active); 品号状态1: 生效; 品名: 贴片电阻; 品牌: Yageo/Phicomp/厚声; 确认状态: 确认; 湿敏: 0; 新品号: 21-1-000024; 英文名: Chip Resistor; 制造商型号: RC0603FR-0710KL/0603WAF1002T5E; ABC等级1: C; Component Kind: Standard; Pin: 2; 创建日期: 2015/5/8 12:25:50; 限量: 0; Library Name: Hansong-Standard SCH Library.SchLib
R109 R110 = RR: Resistor; ABC等级: C; 封装: 0603; 计量单位: pcs; 交期(天): 49; 旧品号: 07-94702-00; 品号规格: 47KΩ,0603,1/10W,±1%,RC0603FR-0747KL; 品号类型: 采购件; 品号状态: 正常(Active); 品号状态1: 生效; 品名: 贴片电阻; 品牌: YAGEO/Phicomp; 确认状态: 确认; 湿敏: 0; 新品号: 21-1-000090; 英文名: Chip Resistor; 制造商型号: RC0603FR-0747KL; ABC等级1: C; Component Kind: Standard; Pin: 2; 创建日期: 2015/5/8 13:45:10; 限量: 0; Library Name: Hansong-Standard SCH Library.SchLib
R111 = RR: Resistor; ABC等级: C; 封装: 0603; 计量单位: pcs; 交期(天): 49; 旧品号: 07-92432-00; 品号规格: 24.3KΩ,0603,1/10W,±1%,RC0603FR-0724K3L; 品号类型: 采购件; 品号状态: 正常(Active); 品号状态1: 生效; 品名: 贴片电阻; 品牌: Yageo/Phicomp; 确认状态: 确认; 湿敏: 0; 新品号: 21-1-000860; 英文名: Chip Resistor; ABC等级1: C; Component Kind: Standard; Pin: 2; 创建日期: 2015/5/8 13:48:31; 限量: 0; Library Name: Hansong-Standard SCH Library.SchLib
R112 = RR: Resistor; ABC等级: C; 封装: 0603; 计量单位: pcs; 交期(天): 49; 品号规格: 140Ω,0603,1/10W,±1%,RC0603FR-07140RL; 品号类型: 采购件; 品号状态: 正常(Active); 品号状态1: 生效; 品名: 贴片电阻; 品牌: Yageo; 确认状态: 确认; 湿敏: 0; 新品号: 21-1-002060; 英文名: Chip Resistor; 制造商型号: RC0603FR-07140RL; ABC等级1: C; Component Kind: Standard; Pin: 2; 创建日期: 2017/5/31 13:31:39; 限量: 0; Library Name: Hansong-Standard SCH Library.SchLib
R113-R116 R135 R136 R138 R140 R146 = RR: Resistor; ABC等级: C; 封装: 0402; 计量单位: pcs; 交期(天): 49; 旧品号: 07-90103-08; 品号规格: 10KΩ,0402,1/16W,±5%,RC0402JR-0710KL; 品号类型: 采购件; 品号状态: 正常(Active); 品号状态1: 生效; 品名: 贴片电阻; 品牌: Yageo; 确认状态: 确认; 湿敏: 0; 新品号: 21-1-000151; 英文名: Chip Resistor; 制造商型号: RC0402JR-0710KL; ABC等级1: C; Component Kind: Standard; Pin: 2; 创建日期: 2015/5/8 13:45:16; 限量: 0; Library Name: Hansong-Standard SCH Library.SchLib
R123-R126 = RR: Resistor; ABC等级: C; 封装: 0603; 计量单位: pcs; 交期(天): 49; 旧品号: 07-94999-00; 品号规格: 49.9Ω,0603,1/10W,±1%,RC0603FR-0749R9L; 品号类型: 采购件; 品号状态: 正常(Active); 品号状态1: 生效; 品名: 贴片电阻; 品牌: Yageo/Phicomp; 确认状态: 确认; 湿敏: 0; 新品号: 21-1-000098; 英文名: Chip Resistor; 制造商型号: RC0603FR-0749R9L; ABC等级1: C; Component Kind: Standard; Pin: 2; 创建日期: 2015/5/8 13:45:11; 限量: 0; Library Name: Hansong-Standard SCH Library.SchLib
R139 = RR: Resistor; ABC等级: C; 封装: 0402; 计量单位: pcs; 交期(天): 49; 旧品号: 07-91501-08; 品号规格: 1.5KΩ,0402,1/16W,±1%,RC0402FR-071K5L; 品号类型: 采购件; 品号状态: 正常(Active); 品号状态1: 生效; 品名: 贴片电阻; 品牌: YAGEO/FH; 确认状态: 确认; 湿敏: 0; 新品号: 21-1-000653; 英文名: Chip Resistor; ABC等级1: C; Component Kind: Standard; Pin: 2; 创建日期: 2015/5/8 13:47:34; 限量: 0; Library Name: Hansong-Standard SCH Library.SchLib
R141 = RR: Resistor; ABC等级: C; 封装: 0402; 计量单位: pcs; 交期(天): 49; 旧品号: 07-91822-08; 品号规格: 18.2KΩ,0402,1/16W,±1%,RC0402FR-0718K2L; 品号类型: 采购件; 品号状态: 正常(Active); 品号状态1: 生效; 品名: 贴片电阻; 品牌: YAGEO; 确认状态: 确认; 湿敏: 0; 新品号: 21-1-000732; 英文名: Chip Resistor; 制造商: RC0402FR-0718K2L; ABC等级1: C; Component Kind: Standard; Pin: 2; 创建日期: 2015/5/8 13:48:00; 限量: 0; 制造商型号: RC0402FR-0718K2L; Library Name: Hansong-Standard SCH Library.SchLib
R145 = RR: Resistor; ABC等级: C; 封装: 0603; 计量单位: pcs; 交期(天): 49; 旧品号: 07-94871-00; 品号规格: 4.87KΩ,0603,1/10W,±1%,RC0603FR-074K87L; 品号类型: 采购件; 品号状态: 正常(Active); 品号状态1: 生效; 品名: 贴片电阻; 品牌: Yageo; 确认状态: 确认; 湿敏: 0; 新品号: 21-1-000096; 英文名: Chip Resistor; 制造商型号: RC0603FR-074K87L; ABC等级1: C; Component Kind: Standard; Pin: 2; 创建日期: 2015/5/8 13:45:10; 限量: 0; Library Name: Hansong-Standard SCH Library.SchLib
TP101 TP102 = TP: Test Point; Component Kind: Standard; Library Name: Hansong-Standard SCH Library.SchLib
U101 = TLV70433: 03-70433-01; 新品号: 11-1-000823; Component Kind: Standard
U103 = IC-TQFP-128PN-(TM4C1294NCPDT): ABC等级: A; 计量单位: pcs; 交期(天): 90; 旧品号: 03-01294-01; 品号规格: TM4C1294NCPDTI3; 品号类型: 采购件; 品号状态: 正常(Active); 品号状态1: 生效; 品名: 贴片M4 MCU; 品牌: TI; 确认状态: 确认; 湿敏: 3; 限量: 0; 新品号: 11-1-000012; 英文名: M4 MCU; ABC等级1: A; Component Kind: Standard; Description: TM4C1294NCPDT; Pin: 128; 创建日期: 2015/5/8 12:17:56; 封装: TQFP-128; 制造商型号: TM4C1294NCPDTI3; Library Name: SCHLIB POE.SchLib
U104 = ICS-8PN-(MX25L6406E): MX25L6406EM2I-12G; ABC等级: B; 封装: SOIC-8; 计量单位: pcs; 交期(天): 56; 品号规格: AT25DF081A-SSH-T,SOIC-8; 品号类型: 采购件; 品号状态: 正常(Active); 品号状态1: 生效; 品名: SPI存储器; 品牌: ADESTO TECHNOLOGIES; 确认状态: 确认; 湿敏: 1; 限量: 0; 新品号: 11-1-002135; 英文名: SPI FLASH; ABC等级1: B; Component Kind: Standard; Pin: 8; 创建日期: 2019/7/10 11:50:36; 制造商型号: AT25DF081A-SSH-T; Library Name: Hansong-Standard SCH Library.SchLib
U105 = ICS-8PN-M24256-BRMN6TP: ABC等级: A; 封装: SOIC-8; 计量单位: pcs; 交期(天): 28; 旧品号: 03-24256-04; 品号类型: 采购件; 品号状态: 正常(Active); 品号状态1: 生效; 品名: 贴片电可擦写存储器; 品牌: ST; 确认状态: 确认; 湿敏: 3; 限量: 0; 新品号: 11-1-000721; 英文名: EEPROM; ABC等级1: A; Component Kind: Standard; Library Name: HS40-1177-BOARD-V1.0.SCHLIB; Pin: 8; 创建日期: 2015/5/8 13:41:59; 品号规格: M24256-BRMN6TP; 制造商型号: M24256-BRMN6TP
Y101 = XL-4PN: XTAL; ABC等级: B; 封装: 3.2X2.5MM; 计量单位: pcs; 交期(天): 56; 旧品号: 04-12502-03; 品号规格: 25MHZ,20PF,30PPM,X3S025000FK1H; 品号类型: 采购件; 品号状态: 正常(Active); 品号状态1: 生效; 品名: 贴片晶振; 品牌: 加高; 确认状态: 确认; 湿敏: 0; 新品号: 14-1-000040; 英文名: Crystal; 制造商: X3S025000FK1H; ABC等级1: B; Component Kind: Standard; Pin: 4; 创建日期: 2015/5/8 12:25:25; 限量: 0; 制造商型号: X3S025000FK1H; Library Name: Hansong-Standard SCH Library.SchLib
J101 = HR951198A: Component Kind: Standard; Library Name: HS40-1177-BOARD-V1.0.SCHLIB
M1-M4 = MARK: Component Kind: Standard; Description: MARK; Library Name: Hansong-Standard SCH Library.SchLib
U102 = IC-SI3402-B: Component Kind: Standard; Library Name: Project_POE.SCHLIB
